# Altium schematic sheet POWER.SchDoc
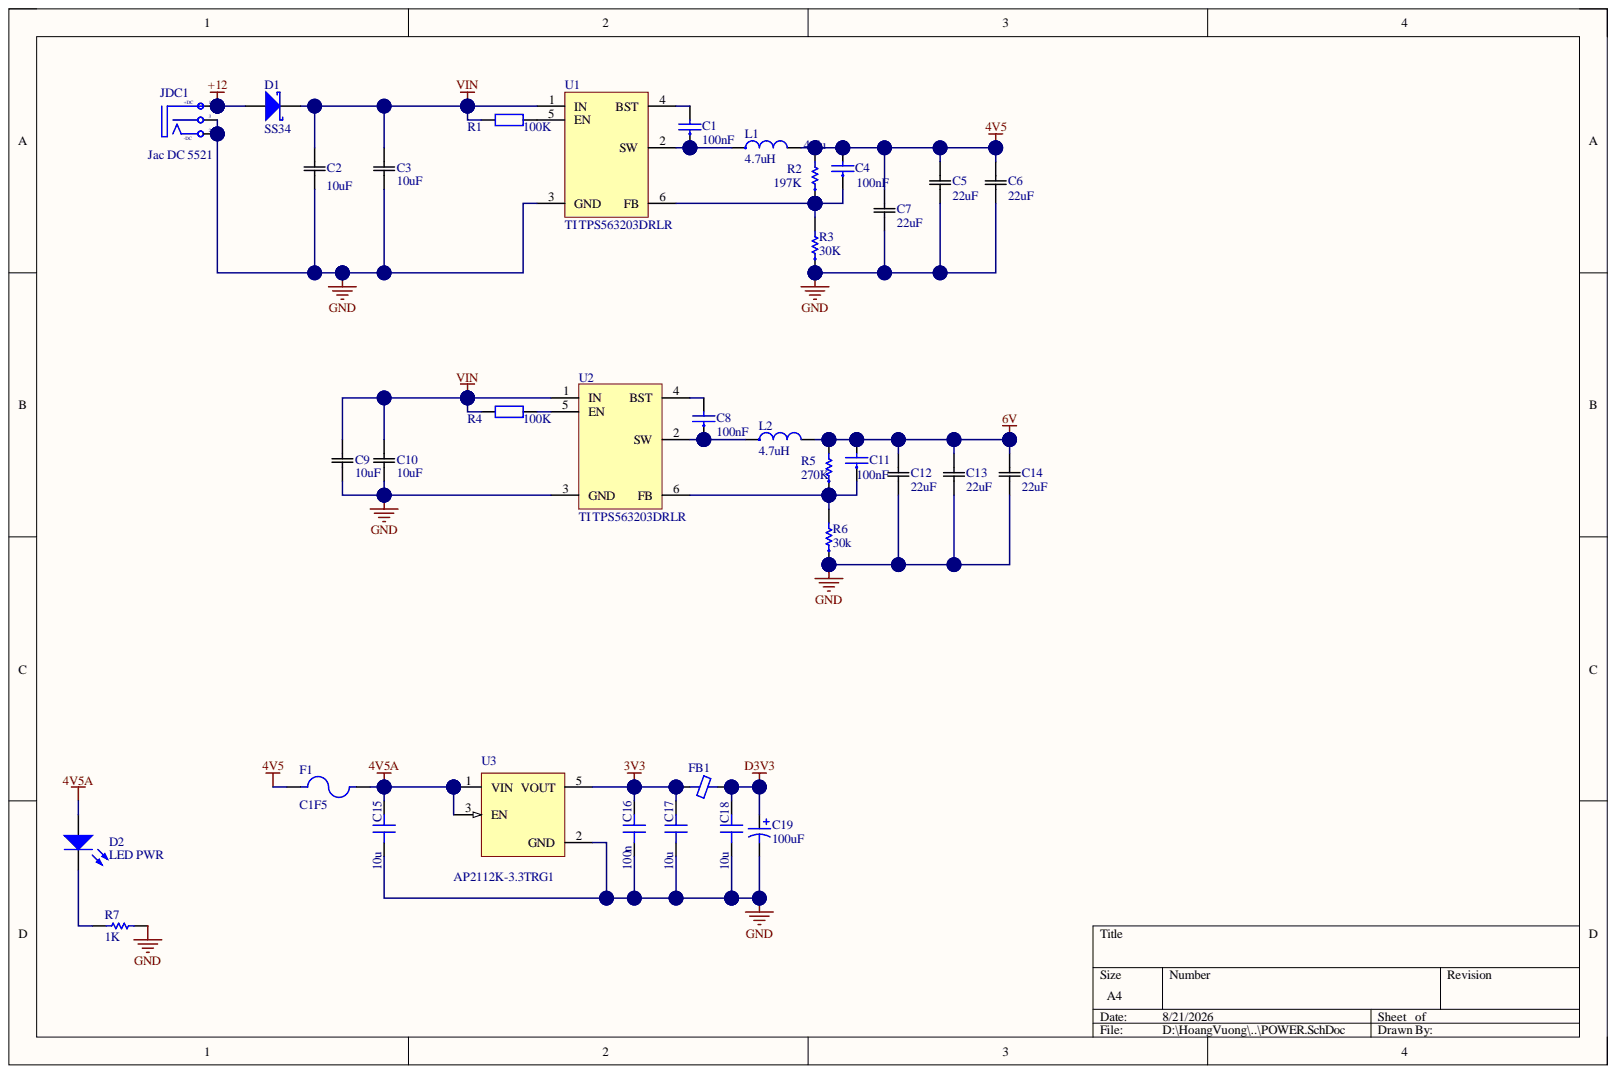
[diagram p0: POWER.SchDoc]

## Parts
C1  100nF  [0603]
C2  10uF  [CAP_CL10_SAM]
C3  10uF  [CAP_CL10_SAM]
C4  100nF  [0603]
C5  22uF  [CN-SMD-0603]
C6  22uF  [CN-SMD-0603]
C7  22uF  [CN-SMD-0603]
C8  100nF  [0603]
C9  10uF  [CAP_CL10_SAM]
C10  10uF  [CAP_CL10_SAM]
C11  100nF  [0603]
C12  22uF  [CN-SMD-0603]
C13  22uF  [CN-SMD-0603]
C14  22uF  [CN-SMD-0603]
C15  10u  [CN-SMD-0603]
C16  100n  [CN-SMD-0603]
C17  10u  [CN-SMD-0603]
C18  10u  [CN-SMD-0603]
C19  value 100uF  [CAP-ALU-SMD-D]  (hidden comment: CAP ALUM 100UF 20% 16V SMD)
D1  SS34  [DIO-SMD-106]
D2  value LED PWR  [LED-0805-Red]  (hidden comment: LED Red)
F1  C1F5  [RES-SMD-1206]
FB1  330  [IND_BLM18KG331WH1D_MUR-L]  (value not drawn)
JDC1  Jac DC 5521  value Jac DC  [DC0]
L1  4.7uH  value 4.7u  [Inductor_SMD]
L2  4.7uH  [Inductor_SMD]
R1  value 100K  [RES-SMD-0603]  (hidden comment: RES 100K 5% 1/10W 0603)
R2  197K  [0603]
R3  30K  [0603]
R4  value 100K  [RES-SMD-0603]  (hidden comment: RES 100K 5% 1/10W 0603)
R5  270K  [0603]
R6  30k  [0603]
R7  1K  [RES-SMD-0603]
U1  TI TPS563203DRLR  [SOT_07SDRLR_TEX]
U2  TI TPS563203DRLR  [SOT_07SDRLR_TEX]
U3  AP2112K-3.3TRG1  [SOT_RG1_DIO]

## Nets
3V3: C16.2 C17.2 FB1.1 U3.5(VOUT)
4V5: C4.2 C5.2 C6.2 C7.2 F1.1 L1.2 R2.2
4V5A: C15.2 D2.1 F1.2 U3.1(VIN) U3.3(EN)
6V: C11.2 C12.2 C13.2 C14.2 L2.2 R5.2
+12: D1.1 JDC1.1
D3V3: C18.2 C19.1 FB1.2
GND: C2.1 C3.1 C5.1 C6.1 C7.1 C9.1 C10.1 C12.1 C13.1 C14.1 C15.1 C16.1 C17.1 C18.1 C19.2 JDC1.2 JDC1.3 R3.1 R6.1 R7.2 U1.3(GND) U2.3(GND) U3.2(GND)
VIN: C2.2 C3.2 C9.2 C10.2 D1.2 R1.2 R4.2 U1.1(IN) U2.1(IN)
NetC1_1: C1.1 L1.1 U1.2(SW)
NetC1_2: C1.2 U1.4(BST)
NetC4_1: C4.1 R2.1 R3.2 U1.6(FB)
NetC8_1: C8.1 L2.1 U2.2(SW)
NetC8_2: C8.2 U2.4(BST)
NetC11_1: C11.1 R5.1 R6.2 U2.6(FB)
NetD2_2: D2.2 R7.1
NetR1_1: R1.1 U1.5(EN)
NetR4_1: R4.1 U2.5(EN)

## Library data of the parts
C1 C8 = CAPCER0001 100nF 10% 50V X7R 0603 SMD_1: CAP CER 100nF 10% 50V X7R 0603 SMD; CERTIFICATION: NA; CLASS: B; LINE: SMT; TMG CODE: None; TYPE: EP; UNIT: PCS; Value: 100n; Component Kind: Standard; ComponentLink1Description: Datasheet; ComponentLink1URL: https://datasheet.lcsc.com/lcsc/1811021431_Samsung-Electro-Mechanics-CL10B104KB8NNNC_C1591.pdf; MOUNT: SMD; Simulation: C_Capacitor_Default; TMG PN: CAPCER0001; Library Name: 2502-GMQLITE-MAIN.SCHLIB
C2 C10 = CL10A106MQ8NNNC: Description; Manufacturer_Name: Samsung; Component Kind: Standard; Library Name: CL10A106MQ8NNNC.SchLib; Manufacturer_Part_Number: CL10A106MQ8NNNC; Simulation: C_Capacitor_Default; Copyright: Copyright (C) 2026 Ultra Librarian. All rights reserved.
  C2: Value: 10uF
C3 C9 = CL10A106MQ8NNNC: Description; Manufacturer_Name: Samsung; Value: 10u; Component Kind: Standard; Library Name: CL10A106MQ8NNNC.SchLib; Manufacturer_Part_Number: CL10A106MQ8NNNC; Simulation: C_Capacitor_Default; Copyright: Copyright (C) 2026 Ultra Librarian. All rights reserved.
C4 = CAPCER0001 100nF 10% 50V X7R 0603 SMD: CAP CER 100nF 10% 50V X7R 0603 SMD; CERTIFICATION: NA; CLASS: B; LINE: SMT; TMG CODE: None; TYPE: EP; UNIT: PCS; Component Kind: Standard; ComponentLink1Description: Datasheet; ComponentLink1URL: https://datasheet.lcsc.com/lcsc/1811021431_Samsung-Electro-Mechanics-CL10B104KB8NNNC_C1591.pdf; MOUNT: SMD; Simulation: C_Capacitor_Default; TMG PN: CAPCER0001; Library Name: 2502-GMQLITE-MAIN.SCHLIB
C5-C7 C12 C13 = GRM188R60J226MEA0D: Description; Manufacturer_Name: Murata; Value: 22u; Component Kind: Standard; Library Name: GRM188R60J226MEA0D.SchLib; Manufacturer_Part_Number: GRM188R60J226MEA0D; Simulation: C_Capacitor_Default; Copyright: Copyright (C) 2026 Ultra Librarian. All rights reserved.
C11 = CAPCER0001 100nF 10% 50V X7R 0603 SMD: CAP CER 100nF 10% 50V X7R 0603 SMD; CERTIFICATION: NA; CLASS: B; LINE: SMT; TMG CODE: None; TYPE: EP; UNIT: PCS; Value: 100n; Component Kind: Standard; ComponentLink1Description: Datasheet; ComponentLink1URL: https://datasheet.lcsc.com/lcsc/1811021431_Samsung-Electro-Mechanics-CL10B104KB8NNNC_C1591.pdf; MOUNT: SMD; Simulation: C_Capacitor_Default; TMG PN: CAPCER0001; Library Name: 2502-GMQLITE-MAIN.SCHLIB
C14 = GRM188R60J226MEA0D: Description; Manufacturer_Name: Murata; Component Kind: Standard; Library Name: GRM188R60J226MEA0D.SchLib; Manufacturer_Part_Number: GRM188R60J226MEA0D; Simulation: C_Capacitor_Default; Copyright: Copyright (C) 2026 Ultra Librarian. All rights reserved.
C15 C17 C18 = GMC10X7R106K10NT: CAP0603 X7R 10UF 10% 10V; manufacturer: Cal-Chip Electronics, Inc.; Capacitance: 10 µF; Database Table Name: Capacitors - Ceramic - 0603; Applications: General Purpose; Component Kind: Standard; componentlink1description: Datasheet; componentlink1url: https://88ce57.p3cdn1.secureserver.net/wp-content/uploads/2023/01/gmc_series-2.pdf; componentlink2description: DigiKey Link; componentlink2url: https://www.digikey.com/en/products/detail/cal-chip-electronics-inc/GMC10X7R106K10NT/18151126; Device Package: 0603 (1608 Metric); lastupdated: 2/9/2024 8:09:39 PM; Library Name: Celestial Altium Library - altium_library.DbLib; Lifecycle Status: Active; Minimum Order: 1; Mounting Type: Surface Mount, MLCC; Operating Temperature: -55°C ~ 125°C; Package / Case: 0603 (1608 Metric); packaging: TapeAndReel; Part Number: GMC10X7R106K10NT; Part Status: Active; partid: 49835; price: 0.26; series: GMC; Simulation: C_Capacitor_Default; Size / Dimension: 0.063" L x 0.031" W (1.60mm x 0.80mm); Supplier 1: DigiKey; Supplier Part Number 1: 4713-GMC10X7R106K10NTCT-ND; Temperature Coefficient: X7R; Thickness (Max): 0.039" (1.00mm); Tolerance: ±10%; Value: 10u; Voltage - Rated: 10V
C16 = GMC10X7R106K10NT: CAP0603 X7R 100nF 10% 10V; manufacturer: Cal-Chip Electronics, Inc.; Capacitance: 10 µF; Database Table Name: Capacitors - Ceramic - 0603; Applications: General Purpose; Component Kind: Standard; componentlink1description: Datasheet; componentlink1url: https://88ce57.p3cdn1.secureserver.net/wp-content/uploads/2023/01/gmc_series-2.pdf; componentlink2description: DigiKey Link; componentlink2url: https://www.digikey.com/en/products/detail/cal-chip-electronics-inc/GMC10X7R106K10NT/18151126; Device Package: 0603 (1608 Metric); lastupdated: 2/9/2024 8:09:39 PM; Library Name: Celestial Altium Library - altium_library.DbLib; Lifecycle Status: Active; Minimum Order: 1; Mounting Type: Surface Mount, MLCC; Operating Temperature: -55°C ~ 125°C; Package / Case: 0603 (1608 Metric); packaging: TapeAndReel; Part Number: GMC10X7R106K10NT; Part Status: Active; partid: 49835; price: 0.26; series: GMC; Simulation: C_Capacitor_Default; Size / Dimension: 0.063" L x 0.031" W (1.60mm x 0.80mm); Supplier 1: DigiKey; Supplier Part Number 1: 4713-GMC10X7R106K10NTCT-ND; Temperature Coefficient: X7R; Thickness (Max): 0.039" (1.00mm); Tolerance: ±10%; Value: 100n; Voltage - Rated: 10V
C19 = UWT1C101MCL1GB: CAP ALUM 100UF 20%   16V SMD; Component Kind: Standard; Library Name: capacitor.SchLib; Signal Integrity: Cap Pol; Simulation: C_Capacitor_Default; Supplier 1: Digi-Key; Supplier Part Number 1: 493-2177-2-ND
D1 = SS34: Schottky Diode 40V 3A SMA; Component Kind: Standard; Library Name: diode.SchLib; Signal Integrity: D Schottky; Simulation: diode; Supplier 1: Digi-Key; Supplier Part Number 1: SS34-E3/57TGICT-ND; Value: SS34
D2 = LTST-C190KRKT: Lite-On LED Red 0603; Component Kind: Standard; Library Name: diode.SchLib; Simulation: diode; Supplier 1: Digi-Key; Supplier Part Number 1: 160-1436-1-ND; Supplier Part Number 2: FL1413
F1 = C1F5: Fuse Chip Fast Acting 5A 125V SMD Solder Pad 1206 3.2 X 1.58 X 0.63mm; Manufacturer: Bel TRP; DC Cold Resistance: 20mR; Fuse Type: Board Mount (Cartridge Style Excluded); Voltage Rating (DC): 63V; Case Code (Imperial): 1206; Case Code (Metric): 3216; Case/Package: 1206; Category: Uncategorized; Component Kind: Standard; ComponentLink1Description: http://www.mouser.com/ds/2/643/C1F-594910.pdf?src-supplier=Mouser; ComponentLink1URL: http://www.mouser.com/ds/2/643/C1F-594910.pdf?src-supplier=Mouser; ComponentLink2Description: http://belfuse.com/pdfs/C1F.pdf?src-supplier=Avnet; ComponentLink2URL: http://belfuse.com/pdfs/C1F.pdf?src-supplier=Avnet; ComponentLink3Description: https://belfuse.com/resources/datasheets/circuitprotection/ds-cp-c1f-series.pdf?src-supplier=Digikey; ComponentLink3URL: https://belfuse.com/resources/datasheets/circuitprotection/ds-cp-c1f-series.pdf?src-supplier=Digikey; Contact Plating: Tin; Library Name: POWER_PIN_CAMERA.SchLib; Manufacturer Part Number: C1F5; Max Operating Temperature: 125°C; Min Operating Temperature: -55°C; Mount: Surface Mount; Number of Pins: 2; Packaging: Cut Tape; RoHS: Yes; Series: C1F; Simulation: R_Resistor_Default; Supplier 1: Newark; Supplier Part Number 1: 48AC8480; Voltage Rating: 125V; Voltage Rating (AC): 125V
FB1 = BLM18KG331SH1D: FERRITE BEAD 330 OHM 0603 1LN; Manufacturer: Murata Electronics; Current Rating (Max): 1.7A; Database Table Name: Filters - Ferrite Beads and Chips; DC Resistance (DCR) (Max): 80mOhm; Filter Type: Power Line; Impedance @ Frequency: 330 Ohms @ 100 MHz; Number of Lines: 1; Component Kind: Standard; ComponentLink1Description: Datasheet; ComponentLink1URL: https://www.murata.com/en-global/products/productdata/8796737273886/QNFA9101.pdf; ComponentLink2Description: DigiKey Link; ComponentLink2URL: https://www.digikey.com/en/products/detail/murata-electronics/BLM18KG331SH1D/5881246; Device Package: 0603 (1608 Metric); Height (Max): 0.037" (0.95mm); LastUpdated: 3/11/2024 7:43:28 AM; Library Name: Celestial Altium Library - altium_library.DbLib; Lifecycle Status: Active; Minimum Order: 1; Mounting Type: Surface Mount; Operating Temperature: -55°C ~ 125°C; Package / Case: 0603 (1608 Metric); Packaging: TapeAndReel; Part Number: BLM18KG331SH1D; Part Status: Active; PartId: 932; Price: 0.02; Ratings: AEC-Q200; Series: EMIFIL®, BLM18; Simulation: R_Resistor_Default; Size / Dimension: 0.063" L x 0.032" W (1.60mm x 0.80mm); Supplier 1: DigiKey; Supplier Part Number 1: 490-13257-1-ND; Value: 330
JDC1 = DCIN: Jac DC input; Component Kind: Standard; Library Name: 2502-GMQLITE-MAIN.SCHLIB
L1 = INDMOI0016 1W2T 2.2uH 20% 5A 0420 SMD: IND MOI 1W2T 4.7uH 20% 5A 0420 SMD; CERTIFICATION: NA; CLASS: B; LINE: SMT; TMG CODE: None; TYPE: EP; UNIT: PCS; Component Kind: Standard; ComponentLink1Description: Datasheet; ComponentLink1URL: https://datasheet.lcsc.com/lcsc/2305161117_cjiang--Changjiang-Microelectronics-Tech-FXL0420-2R2-M_C167206.pdf; MOUNT: SMD; Simulation: L_Inductor_Default; Library Name: 2502-GMQLITE-MAIN.SCHLIB
L2 = INDMOI0016 1W2T 2.2uH 20% 5A 0420 SMD: IND MOI 1W2T 4.7uH 20% 5A 0420 SMD; CERTIFICATION: NA; CLASS: B; LINE: SMT; TMG CODE: None; TYPE: EP; UNIT: PCS; Value: 4.7u; Component Kind: Standard; ComponentLink1Description: Datasheet; ComponentLink1URL: https://datasheet.lcsc.com/lcsc/2305161117_cjiang--Changjiang-Microelectronics-Tech-FXL0420-2R2-M_C167206.pdf; MOUNT: SMD; Simulation: L_Inductor_Default; Library Name: 2502-GMQLITE-MAIN.SCHLIB
R1 R4 = RC0603JR-07100KL: Yageo RES 100K 5% 1/10W 0603; Component Kind: Standard; Library Name: resistor.SchLib; Signal Integrity: Res; Simulation: RESISTOR; Supplier 1: Digi-Key; Supplier Part Number 1: 311-100KGRCT-ND; Supplier Part Number 2: FL2402
R2 R3 R5 R6 = RESCHP0195 2K7 1% 0603 SMD: RES CHP 2K7 1% 1/10W 0603 SMD; CERTIFICATION: NA; CLASS: B; LINE: SMT; TMG CODE: None; UNIT: PCS; Component Kind: Standard; ComponentLink1Description: Datasheet; ComponentLink1URL: https://datasheet.lcsc.com/lcsc/2304140030_YAGEO-RC0603FR-072K7L_C114612.pdf; MOUNT: SMD; Simulation: R_Resistor_Default; TMG PN: RESCHP0195; TYPE: EP; Library Name: 2502-GMQLITE-MAIN.SCHLIB
  R3: Value: 30k
R7 = Resistor 2.2K: Res Thick Film 0603 2.2K Ohm 5% 1/10W ±200ppm/°C Molded SMD SMD Paper T/R; Manufacturer: Vishay; Manufacturer Part Number: CRCW06032K20JNEA; Max Power Dissipation: 100mW; Resistance: 2.2kR; Voltage Rating: 75V; Case Code (Imperial): 0603; Case Code (Metric): 1608; Case/Package: 0603; Category: Chip SMD Resistors; Component Kind: Standard; ComponentLink1Description: https://datasheet.ciiva.com/2462/dcrcwe3-2462048.pdf?src-supplier=Digikey; ComponentLink1URL: https://datasheet.ciiva.com/2462/dcrcwe3-2462048.pdf?src-supplier=Digikey; ComponentLink2Description: https://datasheet.ciiva.com/16767/70201119-16767464.pdf?src-supplier=Allied+Electronics; ComponentLink2URL: https://datasheet.ciiva.com/16767/70201119-16767464.pdf?src-supplier=Allied+Electronics; ComponentLink3Description: https://datasheet.ciiva.com/2462/dcrcwe3-2462046.pdf?src-supplier=Digikey; ComponentLink3URL: https://datasheet.ciiva.com/2462/dcrcwe3-2462046.pdf?src-supplier=Digikey; ComponentLink4Description: https://datasheet.ciiva.com/5541/dcrcwe3-109170-5541959.pdf?src-supplier=Mouser; ComponentLink4URL: https://datasheet.ciiva.com/5541/dcrcwe3-109170-5541959.pdf?src-supplier=Mouser; ComponentLink5Description: https://datasheet.ciiva.com/16286/dcrcwe3-16286997.pdf?src-supplier=Future+Electronics; ComponentLink5URL: https://datasheet.ciiva.com/16286/dcrcwe3-16286997.pdf?src-supplier=Future+Electronics; Construction: Rectangular; Contact Plating: Tin; Depth: 0.85mm; Features: Automotive AEC-Q200; Height: 0.45mm; Lead Free: 5697LeadFree; Length: 1.55mm; Library Name: Stm32_Flyback_Driver_250814_1.SCHLIB; Max Operating Temperature: 155°C; Military Standard: Not; Min Operating Temperature: -55°C; Number of Terminations: 2; Package Quantity: 5000; Packaging: Tape and Reel; Power Rating: 100mW; Pricing 1: 5000=0.0053 (USD); Radiation Hardening: No; Ratings: AEC-Q200; Reach SVHC Compliant: Unknown; Resistance Tolerance: 5%; Resistor Type: Thick Film; RoHS: Yes; RoHS Compliant: Yes; Series: CRCW; Simulation: R_Resistor_Default; Size Code: 0603; Stock 1: 130000; Supplier 1: Arrow Electronics; Supplier Part Number 1: CRCW06032K20JNEA; Temperature Coefficient: 200ppm/°C; Termination: SMD/SMT; Tolerance: 5%; Value: 1K; Weight: 7.1E-05oz; Width: 0.85mm
U1 U2 = ICSOCV0021 BCK TPS563201 3A SOT23-6 SMD: ICS OCV BCK TPS563201 3A SOT23-6 SMD; CERTIFICATION: NA; CLASS: B; LINE: SMT; TMG CODE: None; UNIT: PCS; Component Kind: Standard; ComponentLink1Description: Datasheet; ComponentLink1URL: https://datasheet.lcsc.com/lcsc/2304241919_Texas-Instruments-TPS563201DDCR_C116592.pdf; MOUNT: SMD; Simulation: TPS563203_TRANS; TYPE: EP; Library Name: 2502-GMQLITE-MAIN.SCHLIB
U3 = AP2112K-3.3TRG1: AP2112 Series 0.6 A 3.3 V Fixed Output SMT LDO Linear Regulator - SOT23-5; MF: Diodes Inc.; Value: 1K; Availability: Unavailable; Component Kind: Standard; MP: AP2112K-3.3TRG1; Package: SOT-753 Diodes Inc.; Price: None; SnapEDA_Link: https://www.snapeda.com/parts/AP2112K-3.3TRG1/Diodes%20Inc./view-part/?ref=snap; Library Name: hanguyen.SchLib
